# Altium schematic sheet E-scooter.SchDoc(E-scooter)
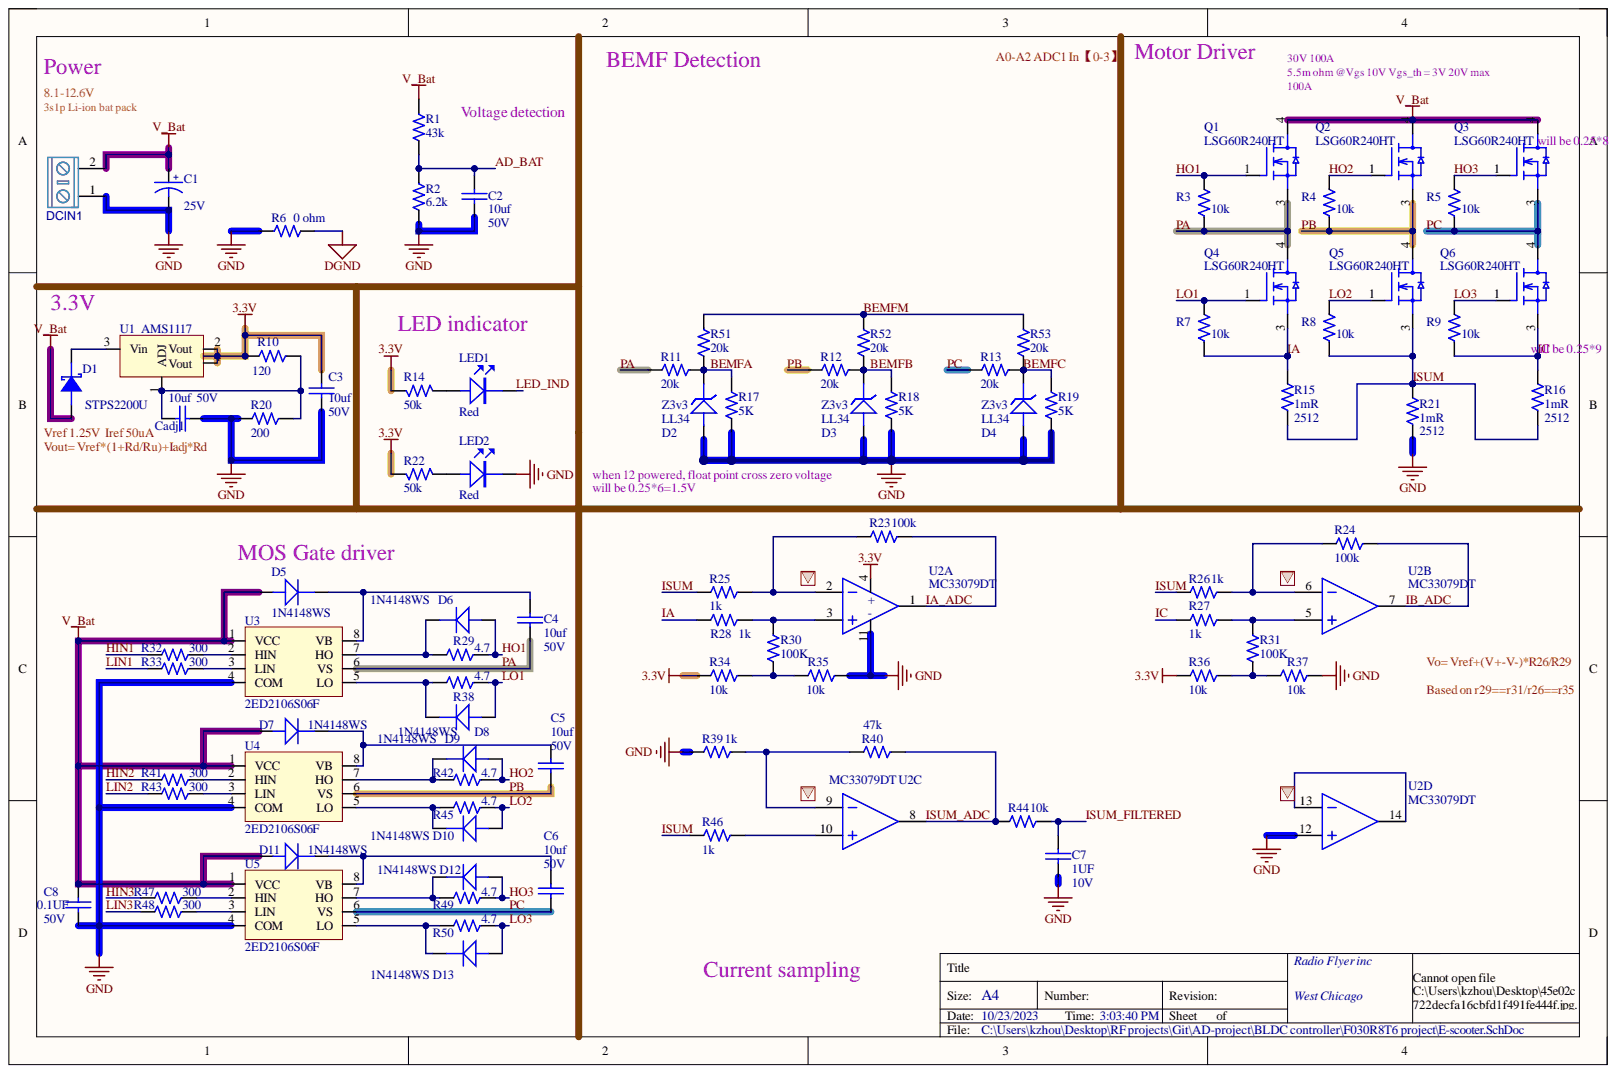
[diagram p0: E-scooter.SchDoc]

## Parts
C1  Voltage: 25V  [CASE - G]  (hidden comment: PBE099550-4710)
C2  value 10uf  Voltage: 50V  [CAPC0603-RW]  (hidden comment: PXL456470-1530)
C3  value 10uf  Voltage: 50V  [CAPC0603-RW]  (hidden comment: PXL456470-1530)
C4  value 10uf  Voltage: 50V  [CAPC0603-RW]  (hidden comment: PXL456470-1530)
C5  value 10uf  Voltage: 50V  [CAPC0603-RW]  (hidden comment: PXL456470-1530)
C6  value 10uf  Voltage: 50V  [CAPC0603-RW]  (hidden comment: PXL456470-1530)
C7  value 1UF  Voltage: 10V  [CAPC0603-SW]  (hidden comment: PXL456470-1530)
C8  value 0.1UF  Voltage: 50V  [CAPC0603-RW]  (hidden comment: PXL456470-1530)
Cadj1  value 10uf  Voltage: 50V  [CAPC0603-RW]  (hidden comment: PXL456470-1530)
D1  MFG Part: STPS2200U  [SMB]  (hidden comment: DIO STPS2200U)
D2  MFG Part: Z3v3  [LL34]  (hidden comment: RCZ005015-0020)
D3  MFG Part: Z3v3  [LL34]  (hidden comment: RCZ005015-0020)
D4  MFG Part: Z3v3  [LL34]  (hidden comment: RCZ005015-0020)
D5  RCD114148-0020  [SOD-323]  (value not drawn)
D6  RCD114148-0020  [SOD-323]  (value not drawn)
D7  RCD114148-0020  [SOD-323]  (value not drawn)
D8  RCD114148-0020  [SOD-323]  (value not drawn)
D9  RCD114148-0020  [SOD-323]  (value not drawn)
D10  RCD114148-0020  [SOD-323]  (value not drawn)
D11  RCD114148-0020  [SOD-323]  (value not drawn)
D12  RCD114148-0020  [SOD-323]  (value not drawn)
D13  RCD114148-0020  [SOD-323]  (value not drawn)
DCIN1  KF/WJ/DG 30x connecter  [KF/WJ/DG 301-2p-5.0mm]  (value not drawn)
LED1  Color: Red  [LED1206-RED]  (hidden comment: LED1206R_23-21\R6C-AM1N2AY\2A)
LED2  Color: Red  [LED1206-RED]  (hidden comment: LED1206R_23-21\R6C-AM1N2AY\2A)
Q1  MFG Part: LSG60R240HT  [TO252-3]  (hidden comment: T5860038503A6)
Q2  MFG Part: LSG60R240HT  [TO252-3]  (hidden comment: T5860038503A6)
Q3  MFG Part: LSG60R240HT  [TO252-3]  (hidden comment: T5860038503A6)
Q4  MFG Part: LSG60R240HT  [TO252-3]  (hidden comment: T5860038503A6)
Q5  MFG Part: LSG60R240HT  [TO252-3]  (hidden comment: T5860038503A6)
Q6  MFG Part: LSG60R240HT  [TO252-3]  (hidden comment: T5860038503A6)
R1  value 43k  [0603-RW]  (hidden comment: T5024421083A9)
R2  value 6.2k  [0603-RW]  (hidden comment: T5024421083A9)
R3  value 10k  [0603-RW]  (hidden comment: T5024421083A9)
R4  value 10k  [0603-RW]  (hidden comment: T5024421083A9)
R5  value 10k  [0603-RW]  (hidden comment: T5024421083A9)
R6  value 0 ohm  [0603-RW]  (hidden comment: T502210202399)
R7  value 10k  [0603-RW]  (hidden comment: T5024421083A9)
R8  value 10k  [0603-RW]  (hidden comment: T5024421083A9)
R9  value 10k  [0603-RW]  (hidden comment: T5024421083A9)
R10  value 120  [0603-RW]  (hidden comment: T5024421083A9)
R11  value 20k  [0603-RW]  (hidden comment: T5024421083A9)
R12  value 20k  [0603-RW]  (hidden comment: T5024421083A9)
R13  value 20k  [0603-RW]  (hidden comment: T5024421083A9)
R14  value 50k  [0603-RW]  (hidden comment: T5024421083A9)
R15  value 1mR  [2512]  (hidden comment: QCF101250-1009)
R16  value 1mR  [2512]  (hidden comment: QCF101250-1009)
R17  value 5K  [0603-RW]  (hidden comment: T5024421083A9)
R18  value 5K  [0603-RW]  (hidden comment: T5024421083A9)
R19  value 5K  [0603-RW]  (hidden comment: T5024421083A9)
R20  value 200  [0603-RW]  (hidden comment: T5024421083A9)
R21  value 1mR  [2512]  (hidden comment: QCF101250-1009)
R22  value 50k  [0603-RW]  (hidden comment: T5024421083A9)
R23  value 100k  [0603-RW]  (hidden comment: T5024421083A9)
R24  value 100k  [0603-RW]  (hidden comment: T5024421083A9)
R25  value 1k  [0603-RW]  (hidden comment: T5024421083A9)
R26  value 1k  [0603-RW]  (hidden comment: T5024421083A9)
R27  value 1k  [0603-RW]  (hidden comment: T5024421083A9)
R28  value 1k  [0603-RW]  (hidden comment: T5024421083A9)
R29  value 4.7  [0603-RW]  (hidden comment: T5024421083A9)
R30  value 100K  [0603-RW]  (hidden comment: T5024421083A9)
R31  value 100K  [0603-RW]  (hidden comment: T5024421083A9)
R32  value 300  [0603-RW]  (hidden comment: T5024421083A9)
R33  value 300  [0603-RW]  (hidden comment: T5024421083A9)
R34  value 10k  [0603-RW]  (hidden comment: T5024421083A9)
R35  value 10k  [0603-RW]  (hidden comment: T5024421083A9)
R36  value 10k  [0603-RW]  (hidden comment: T5024421083A9)
R37  value 10k  [0603-RW]  (hidden comment: T5024421083A9)
R38  value 4.7  [0603-RW]  (hidden comment: T5024421083A9)
R39  value 1k  [0603-RW]  (hidden comment: T5024421083A9)
R40  value 47k  [0603-RW]  (hidden comment: T5024421083A9)
R41  value 300  [0603-RW]  (hidden comment: T5024421083A9)
R42  value 4.7  [0603-RW]  (hidden comment: T5024421083A9)
R43  value 300  [0603-RW]  (hidden comment: T5024421083A9)
R44  value 10k  [0603-RW]  (hidden comment: T5024421083A9)
R45  value 4.7  [0603-RW]  (hidden comment: T5024421083A9)
R46  value 1k  [0603-RW]  (hidden comment: T5024421083A9)
R47  value 300  [0603-RW]  (hidden comment: T5024421083A9)
R48  value 300  [0603-RW]  (hidden comment: T5024421083A9)
R49  value 4.7  [0603-RW]  (hidden comment: T5024421083A9)
R50  value 4.7  [0603-RW]  (hidden comment: T5024421083A9)
R51  value 20k  [0603-RW]  (hidden comment: T5024421083A9)
R52  value 20k  [0603-RW]  (hidden comment: T5024421083A9)
R53  value 20k  [0603-RW]  (hidden comment: T5024421083A9)
U1  AMS1117  [SOT223]
U2  RCI033079-0002  [SO14]  (value not drawn)  (parts A,B,C,D)
U3  T610900027257  [SO8]  (value not drawn)
U4  T610900027257  [SO8]  (value not drawn)
U5  T610900027257  [SO8]  (value not drawn)

## Nets
3.3V: C3.1 R10.2 R14.1 R22.1 R34.2 R36.2 U1.2(Vout) U1.4(Vout) U2.4(+)
DGND: R6.2
GND: C1.2 C2.2 C3.2 C7.1 C8.1 Cadj1.2 D2.1 D3.1 D4.1 DCIN1.1 LED2.2 R2.2 R6.1 R17.2 R18.2 R19.2 R20.2 R21.1 R35.1 R37.1 R39.2 U2.11(-) U2.12 U3.4(COM) U4.4(COM) U5.4(COM)
V_Bat: C1.1 C8.2 D1.1 D5.1 D7.1 D11.1 DCIN1.2 Q1.4 Q2.4 Q3.4 R1.1 U3.1(VCC) U4.1(VCC) U5.1(VCC)
AD_BAT: C2.1 R1.2 R2.1
BEMFA: D2.2 R11.1 R17.1 R51.2
BEMFB: D3.2 R12.1 R18.1 R52.2
BEMFC: D4.2 R13.1 R19.1 R53.2
BEMFM: R51.1 R52.1 R53.1
HIN1: R32.2
HIN2: R41.2
HIN3: R47.2
HO1: D6.1 Q1.1 R3.2 R29.1
HO2: D9.1 Q2.1 R4.2 R42.1
HO3: D12.1 Q3.1 R5.2 R49.1
IA: Q4.3 R7.1 R15.2 R28.2
IA_ADC: R23.1 U2.1
IB_ADC: R24.1 U2.7
IC: Q6.3 R9.1 R16.2 R27.2
ISUM: Q5.3 R8.1 R15.1 R16.1 R21.2 R25.2 R26.2 R46.2
ISUM_ADC: R40.1 R44.2 U2.8
ISUM_FILTERED: C7.2 R44.1
LED_IND: LED1.2
LIN1: R33.2
LIN2: R43.2
LIN3: R48.2
LO1: D8.1 Q4.1 R7.2 R38.1
LO2: D10.1 Q5.1 R8.2 R45.1
LO3: D13.1 Q6.1 R9.2 R50.1
PA: C4.1 Q1.3 Q4.4 R3.1 R11.2 U3.6(VS)
PB: C5.1 Q2.3 Q5.4 R4.1 R12.2 U4.6(VS)
PC: C6.1 Q3.3 Q6.4 R5.1 R13.2 U5.6(VS)
NetC4_2: C4.2 D5.2 U3.8(VB)
NetC5_2: C5.2 D7.2 U4.8(VB)
NetC6_2: C6.2 D11.2 U5.8(VB)
NetCadj1_1: Cadj1.1 R10.1 R20.1 U1.1(ADJ)
NetD1_2: D1.2 U1.3(Vin)
NetD6_2: D6.2 R29.2 U3.7(HO)
NetD8_2: D8.2 R38.2 U3.5(LO)
NetD9_2: D9.2 R42.2 U4.7(HO)
NetD10_2: D10.2 R45.2 U4.5(LO)
NetD12_2: D12.2 R49.2 U5.7(HO)
NetD13_2: D13.2 R50.2 U5.5(LO)
NetLED1_1: LED1.1 R14.2
NetLED2_1: LED2.1 R22.2
NetR23_2: R23.2 R25.1 U2.2
NetR24_2: R24.2 R26.1 U2.6
NetR27_1: R27.1 R31.1 U2.5
NetR28_1: R28.1 R30.1 U2.3
NetR30_2: R30.2 R34.1 R35.2
NetR31_2: R31.2 R36.1 R37.2
NetR32_1: R32.1 U3.2(HIN)
NetR33_1: R33.1 U3.3(LIN)
NetR39_1: R39.1 R40.2 U2.9
NetR41_1: R41.1 U4.2(HIN)
NetR43_1: R43.1 U4.3(LIN)
NetR46_1: R46.1 U2.10
NetR47_1: R47.1 U5.2(HIN)
NetR48_1: R48.1 U5.3(LIN)
NetU2_13: U2.13 U2.14

## Library data of the parts
C1 = CAP E 470uF 25V 20% SMD: CHIP ELECT 470uF 25V 20% D10xL10 105; DIP&SMD: SMD; SAP: T551471445000; Type: Capacitor; Vendor Code: 10010262; Vendor Name: 东莞市晴远电子有限公司; Component Kind: Standard; Customer Specific: N/A; Library Name: P-Capacitors.IntLib; PACKAGE: CASE - G; Tolerance: 20%
C2-C8 = CAP0603 0.015uF 50V 10%: GP CHIP CAP 0.015uF 50V 10% 0603 TYPE X7R; DIP&SMD: SMD; SAP: T542021537508; Type: Capacitor; Vendor Code: 10010262; Vendor Name: 东莞市晴远电子有限公司; Component Kind: Standard; Customer Specific: N/A; Library Name: P-Capacitors.IntLib; Other: X7R; PACKAGE: CAPC0603; Tolerance: 10%
Cadj1 = CAP0603 0.015uF 50V 10%: GP CHIP CAP 0.015uF 50V 10% 0603 TYPE X7R; DIP&SMD: SMD; SAP: T542021537508; Vendor Code: 10010262; Vendor Name: 东莞市晴远电子有限公司; Component Kind: Standard; Customer Specific: N/A; Library Name: P-Capacitors.IntLib; Other: X7R; PACKAGE: CAPC0603; Tolerance: 10%; Type: Capacitor
D1 = DIO STPS2200U: DIODE SCHOTTKY 200V 2A SMB; CREATE_NAME: Nathan; DIP&SMD: SMD; MFG: STMicroelectronics; Component Kind: Standard; CREATE_TIME: 19-Jun-2020; Customer Specific: N/A; Library Name: R-Semiconductors.IntLib; Package: SMB; Type: DIODE
D2-D4 = TCLLZ15V: CHIP ZENER 15V 0.5W MLL34; Current: 5MA; DIP&SMD: SMD; MFG: TAK CHEONG; Power: 500MW; SAP: T573003832016; Tolerance: 5%; Vendor Code: 10009207; Vendor Name: MOBICON HOLDINGS LTD.; Component Kind: Standard; Customer Specific: N/A; Library Name: R-Semiconductors.IntLib; Package: LL34; Type: ZENER; VOLTAGE: 15V
D5-D13 = 1N4148WS: DIODE SW 1N4148 VF=0.7V SOD-323; Current: 150MA; DIP&SMD: SMD; MFG: TW SEMICONDUCTOR; SAP: T572005070208; Voltage: 100V; Component Kind: Standard; Customer Specific: N/A; Library Name: R-Semiconductors.IntLib; MFG Part: 1N4148WS; Package: SOD-323; Type: DIODE
DCIN1 = KF/WJ/DG 30x: 接线端子 2Pin; Component Kind: Standard; Library Name: 灵动SPIN360C 无刷驱动V1.6 集成封装库.IntLib
LED1 LED2 = LED1206R_23-21\R6C-AM1N2AY\2A: CHIP LED RED TYPE 1206; CREATE_NAME: Nathan; DIP&SMD: SMD; MFG: EVERLIGHT; Component Kind: Standard; CREATE_TIME: 18-Aug-2020; Library Name: K-LED-FUSE.IntLib; MFG Part: 23-21\R6C-AM1N2AY\2A; Package: 1206; Type: LED
Q1-Q6 = NMOS LSG60R240HT: NMOS 600V LSG60R240HT TO252( LONTEN K; CREATE_NAME: Nathan; Current: 17A; DIP&SMD: SMD; Type: N-CHANNEL MOSFET; Component Kind: Standard; CREATE_TIME: 12-Oct-2019; Customer Specific: N/A; Library Name: R-Semiconductors.IntLib; MFG: LONTEN; Package: TO252; SAP: T5860038503A6; Voltage: 600V
R1-R5 R7-R14 R17-R20 R22-R53 = RES0201 1/20W 1% 4.42K OHM_WALSIN: CHIP 4.42K OHM 200PPM +-1% 0201 WALS L; MFG Part: WR02X4421FAL; CREATE_NAME: Nathan; DIP&SMD: SMD; MFG: WALSIN (華新); Power: 1/20W; TOL: 1%; Component Kind: Standard; CREATE_TIME: 23-July-2019; Customer Specific: N/A; Library Name: Q-Resistors.IntLib; PACKAGE: 0603; SAP: T5024421083A9; Type: Resistor
R6 = RES0603 1/16W 1% 21K OMH_WALSIN: CHIP 21K OHM 100PPM +-1% 0603 WALS L; CREATE_NAME: Nathan; DIP&SMD: SMD; MFG: WALSIN (華新); Power: 1/10W; TOL: 1%; Component Kind: Standard; CREATE_TIME: 08-Feb-2018; Customer Specific: N/A; Library Name: Q-Resistors.IntLib; Package: 0603; SAP: T502210202399; Type: Resistor
R15 R16 R21 = RES2512 1W 1% 10 OHM: CHIP RES. 10 OHM 1W 1% 2512; DIP&SMD: SMD; SAP: T502100A07399; Vendor Code: 10010262; Vendor Name: 东莞市晴远电子有限公司; Component Kind: Standard; Customer Specific: N/A; Library Name: Q-Resistors.IntLib; PACKAGE: 2512; Power: 1W; TOL: 1%; Type: Resistor
U1 = AMS1117: 800mA LOW DROPOUT VOLTAGE REGULATOR , SOT-223; CREATE_NAME: Nathan; DIP&SMD: SMD; MFG: Advanced Monolithic Systems; Component Kind: Standard; CREATE_TIME: 17-Nov-2020; Customer Specific: N/A; Library Name: R-Semiconductors.IntLib; MFG Part: AMS1117; Package: SOT-223; Type: IC
U2 = MC33079DT: IC opamp quad MC33079 bipolar SO14; DIP&SMD: SMD; MFG: STMICROELECTRONICS; SAP: T610500026102; Component Kind: Standard; Customer Specific: N/A; Library Name: R-Semiconductors.IntLib; MFG Part: MC33079DT; Type: IC
U3-U5 = 2ED2106S06F: DRIVER 2ED2106S06F DSO-8 INFINEO K; CREATE_NAME: Nathan; DIP&SMD: SMD; MFG: Infineon; Component Kind: Standard; CREATE_TIME: 14-Apr-2020; Customer Specific: N/A; Library Name: R-Semiconductors.IntLib; MFG Part: 2ED2106S06F; SAP: T610900027257; Type: IC
